# Altium schematic sheet J20_REC_0_1.SchDoc
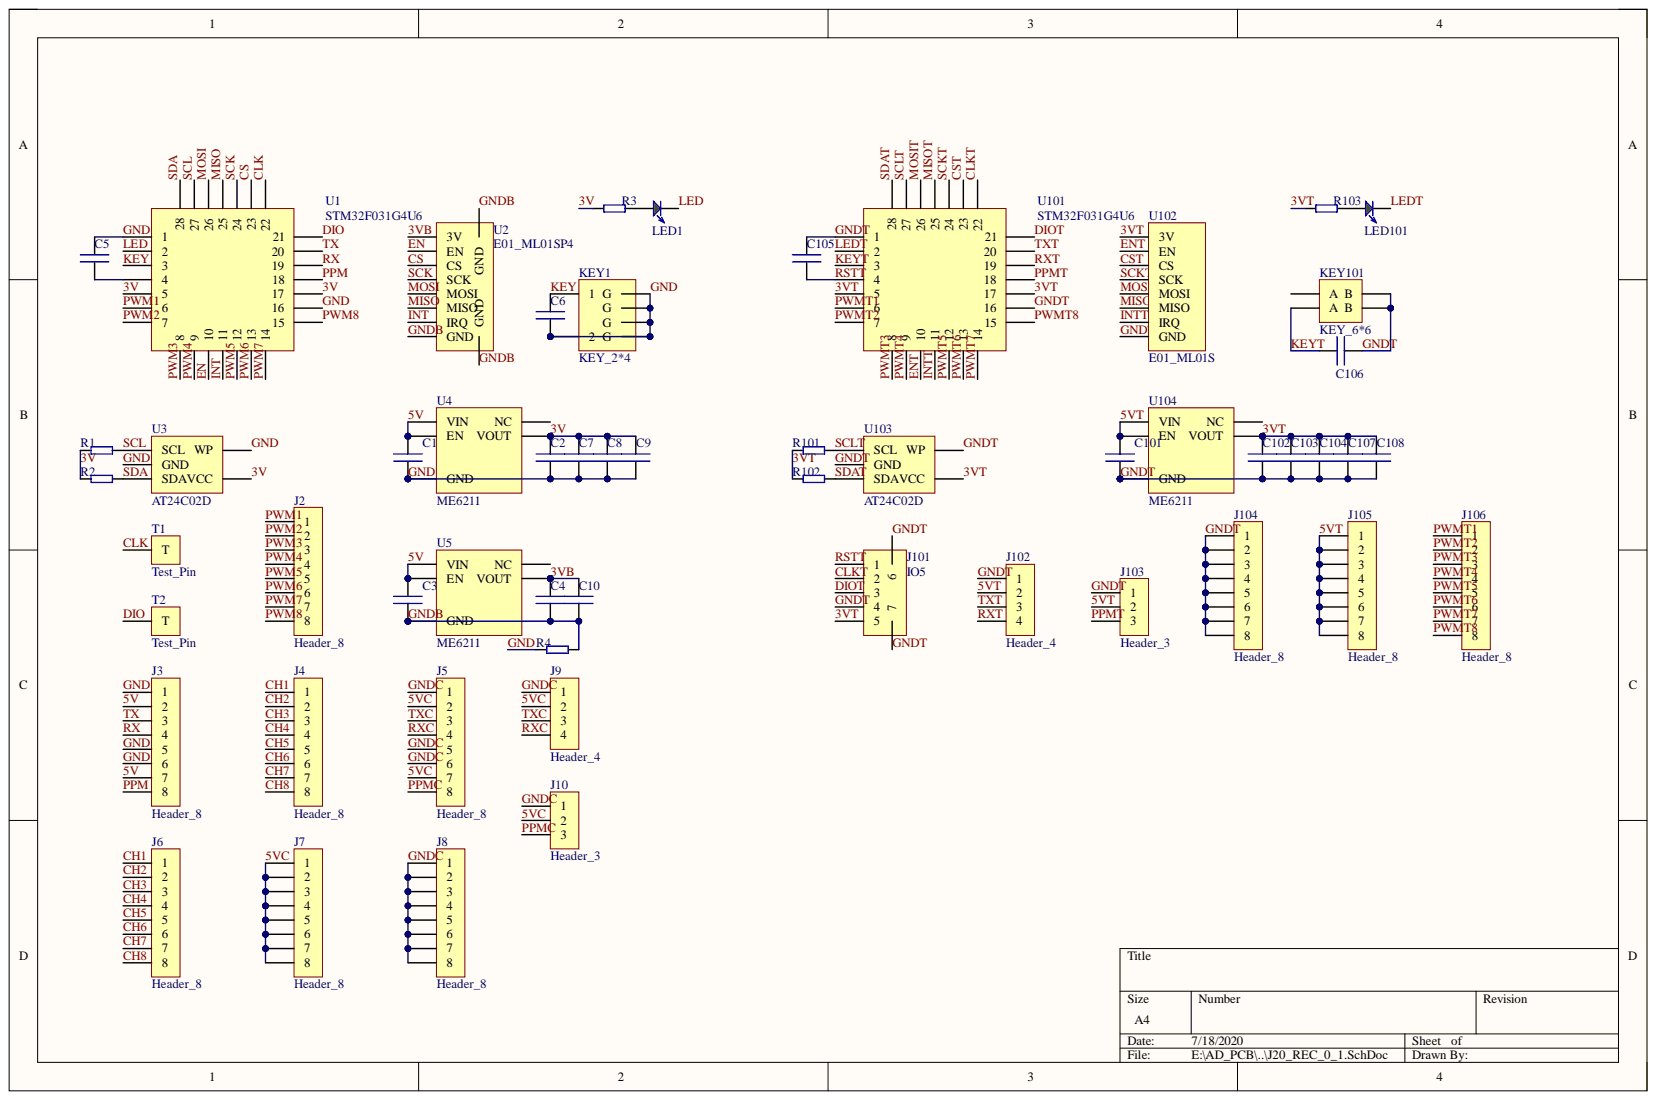
[diagram p0: J20_REC_0_1.SchDoc]

## Parts
C1  CAP  [0603]  (value not drawn)
C2  CAP  [0603]  (value not drawn)
C3  CAP  [0603]  (value not drawn)
C4  CAP  [0603]  (value not drawn)
C5  CAP  [0402]  (value not drawn)
C6  CAP  [0402]  (value not drawn)
C7  CAP  [0402]  (value not drawn)
C8  CAP  [0402]  (value not drawn)
C9  CAP  [0402]  (value not drawn)
C10  CAP  [0603]  (value not drawn)
C101  CAP  [0603]  (value not drawn)
C102  CAP  [0603]  (value not drawn)
C103  CAP  [0402]  (value not drawn)
C104  CAP  [0402]  (value not drawn)
C105  CAP  [0402]  (value not drawn)
C106  CAP  [0402]  (value not drawn)
C107  CAP  [0402]  (value not drawn)
C108  CAP  [0603]  (value not drawn)
J2  Header_8  [Pad_8_2.54]
J3  Header_8  [Pad_8_2.54]
J4  Header_8  [Pad_8_2.54]
J5  Header_8  [Pad_8_2.54]
J6  Header_8  [Header_8_2.54]
J7  Header_8  [Header_8_2.54]
J8  Header_8  [Header_8_2.54]
J9  Header_4  [Header_4_2.54]
J10  Header_3  [Header_3_2.54]
J101  IO5  [GH1.25-5P]
J102  Header_4  [Header_4_2.54]
J103  Header_3  [Header_3_2.54]
J104  Header_8  [Header_8_2.54]
J105  Header_8  [Header_8_2.54]
J106  Header_8  [Header_8_2.54]
KEY1  KEY_2*4  [KEY_2*4]
KEY101  KEY_6*6  [KEY_6*6]
LED1  LED  [0603_LED]
LED101  LED  [0603_LED]
R1  RES  [0402]  (value not drawn)
R2  RES  [0402]  (value not drawn)
R3  RES  [0402]  (value not drawn)
R4  RES  [0805]  (value not drawn)
R101  RES  [0402]  (value not drawn)
R102  RES  [0402]  (value not drawn)
R103  RES  [0402]  (value not drawn)
T1  Test_Pin  [Test_Pin]
T2  Test_Pin  [Test_Pin]
U1  STM32F031G4U6  [UFQFPN-28]
U2  E01_ML01SP4  [E01_ML01SP4]
U3  AT24C02D  [SOT-23-5]
U4  ME6211  [SOT-23-5]
U5  ME6211  [SOT-23-5]
U101  STM32F031G4U6  [UFQFPN-28]
U102  E01_ML01S  [E01_ML01S]
U103  AT24C02D  [SOT-23-5]
U104  ME6211  [SOT-23-5]

## Nets
3V: C2.2 C7.2 C8.2 C9.2 R1.1 R2.1 R3.1 U1.5 U1.17 U3.4(SDAVCC) U4.5(VOUT)
3VB: C4.2 C10.2 U2.1(3V) U5.5(VOUT)
3VT: C102.2 C103.2 C104.2 C107.2 C108.2 J101.5 R101.1 R102.1 R103.1 U101.5 U101.17 U102.1(3V) U103.4(SDAVCC) U104.5(VOUT)
5V: C1.2 C3.2 J3.2 J3.7 U4.1(VIN) U4.3(EN) U5.1(VIN) U5.3(EN)
5VC: J5.2 J5.7 J7.1 J7.2 J7.3 J7.4 J7.5 J7.6 J7.7 J7.8 J9.2 J10.2
5VT: C101.2 J102.2 J103.2 J105.1 J105.2 J105.3 J105.4 J105.5 J105.6 J105.7 J105.8 U104.1(VIN) U104.3(EN)
CH1: J4.1 J6.1
CH2: J4.2 J6.2
CH3: J4.3 J6.3
CH4: J4.4 J6.4
CH5: J4.5 J6.5
CH6: J4.6 J6.6
CH7: J4.7 J6.7
CH8: J4.8 J6.8
CLK: T1.1 U1.22
CLKT: J101.2 U101.22
CS: U1.23 U2.3(CS)
CST: U101.23 U102.3(CS)
DIO: T2.1 U1.21
DIOT: J101.3 U101.21
EN: U1.10 U2.2(EN)
ENT: U101.10 U102.2(EN)
GND: C1.1 C2.1 C5.2 C6.1 C7.1 C8.1 C9.1 J3.1 J3.5 J3.6 KEY1.2 KEY1.3(G) KEY1.4(G) KEY1.5(G) KEY1.6(G) R4.2 U1.1 U1.16 U3.2(GND) U3.5(WP) U4.2(GND)
GNDB: C3.1 C4.1 C10.1 R4.1 U2.8(GND) U2.9(GND) U2.10(GND) U5.2(GND)
GNDC: J5.1 J5.5 J5.6 J8.1 J8.2 J8.3 J8.4 J8.5 J8.6 J8.7 J8.8 J9.1 J10.1
GNDT: C101.1 C102.1 C103.1 C104.1 C105.2 C106.1 C107.1 C108.1 J101.4 J101.6 J101.7 J102.1 J103.1 J104.1 J104.2 J104.3 J104.4 J104.5 J104.6 J104.7 J104.8 KEY101.3(B) KEY101.4(B) U101.1 U101.16 U102.8(GND) U103.2(GND) U103.5(WP) U104.2(GND)
INT: U1.11 U2.7(IRQ)
INTT: U101.11 U102.7(IRQ)
KEY: C6.2 KEY1.1 U1.3
KEYT: C106.2 KEY101.2(A) U101.3
LED: LED1.2 U1.2
LEDT: LED101.2 U101.2
MISO: U1.25 U2.6(MISO)
MISOT: U101.25 U102.6(MISO)
MOSI: U1.26 U2.5(MOSI)
MOSIT: U101.26 U102.5(MOSI)
PPM: J3.8 U1.18
PPMC: J5.8 J10.3
PPMT: J103.3 U101.18
PWM1: J2.1 U1.6
PWM2: J2.2 U1.7
PWM3: J2.3 U1.8
PWM4: J2.4 U1.9
PWM5: J2.5 U1.12
PWM6: J2.6 U1.13
PWM7: J2.7 U1.14
PWM8: J2.8 U1.15
PWMT1: J106.1 U101.6
PWMT2: J106.2 U101.7
PWMT3: J106.3 U101.8
PWMT4: J106.4 U101.9
PWMT5: J106.5 U101.12
PWMT6: J106.6 U101.13
PWMT7: J106.7 U101.14
PWMT8: J106.8 U101.15
RSTT: C105.1 J101.1 U101.4
RX: J3.4 U1.19
RXC: J5.4 J9.4
RXT: J102.4 U101.19
SCK: U1.24 U2.4(SCK)
SCKT: U101.24 U102.4(SCK)
SCL: R1.2 U1.27 U3.1(SCL)
SCLT: R101.2 U101.27 U103.1(SCL)
SDA: R2.2 U1.28 U3.3
SDAT: R102.2 U101.28 U103.3
TX: J3.3 U1.20
TXC: J5.3 J9.3
TXT: J102.3 U101.20
NetC5_1: C5.1 U1.4
NetLED1_1: LED1.1 R3.2
NetLED101_1: LED101.1 R103.2

Not connected: KEY101 1(A); U4 4(NC); U5 4(NC); U104 4(NC)

## Library data of the parts
C1-C10 C101-C108 = CAP: 无极性电容; Component Kind: Standard; Library Name: MySchlib.SchLib
J2-J8 J104-J106 = Header_8: 8P_排针; Component Kind: Standard; Library Name: MySchlib.SchLib
J9 J102 = Header_4: 4P_排针; Component Kind: Standard; Library Name: MySchlib.SchLib
J10 J103 = Header_3: 3P_排针; Component Kind: Standard; Library Name: MySchlib.SchLib
R1-R4 R101-R103 = RES: 电阻; Component Kind: Standard; Library Name: MySchlib.SchLib
T1 T2 = Test_Pin: 测试引脚; Component Kind: Standard; Library Name: MySchlib.SchLib
U1 U101 = QFN28_ALL: STM32F***G*U6; Component Kind: Standard; Library Name: MySchlib.SchLib
U4 U5 U104 = ME6211: 500mA LDO; Component Kind: Standard; Library Name: MySchlib.SchLib
J101 = IO5: Component Kind: Standard; Library Name: MySchlib.SchLib
KEY1 = KEY_2*4: Component Kind: Standard; Library Name: MySchlib.SchLib
KEY101 = KEY_6*6: Component Kind: Standard; Library Name: MySchlib.SchLib
LED1 LED101 = LED: Component Kind: Standard; Description: LED; Library Name: MySchlib.SchLib
U2 = E01_ML01SP4: Component Kind: Standard; Library Name: MySchlib.SchLib
U3 U103 = AT24C02D: Component Kind: Standard; Library Name: MySchlib.SchLib
U102 = E01_ML01S: Component Kind: Standard; Library Name: MySchlib.SchLib
